# Altium schematic sheet 03_IO-CONNECTION.SchDoc(03_IO-CONNECTION)
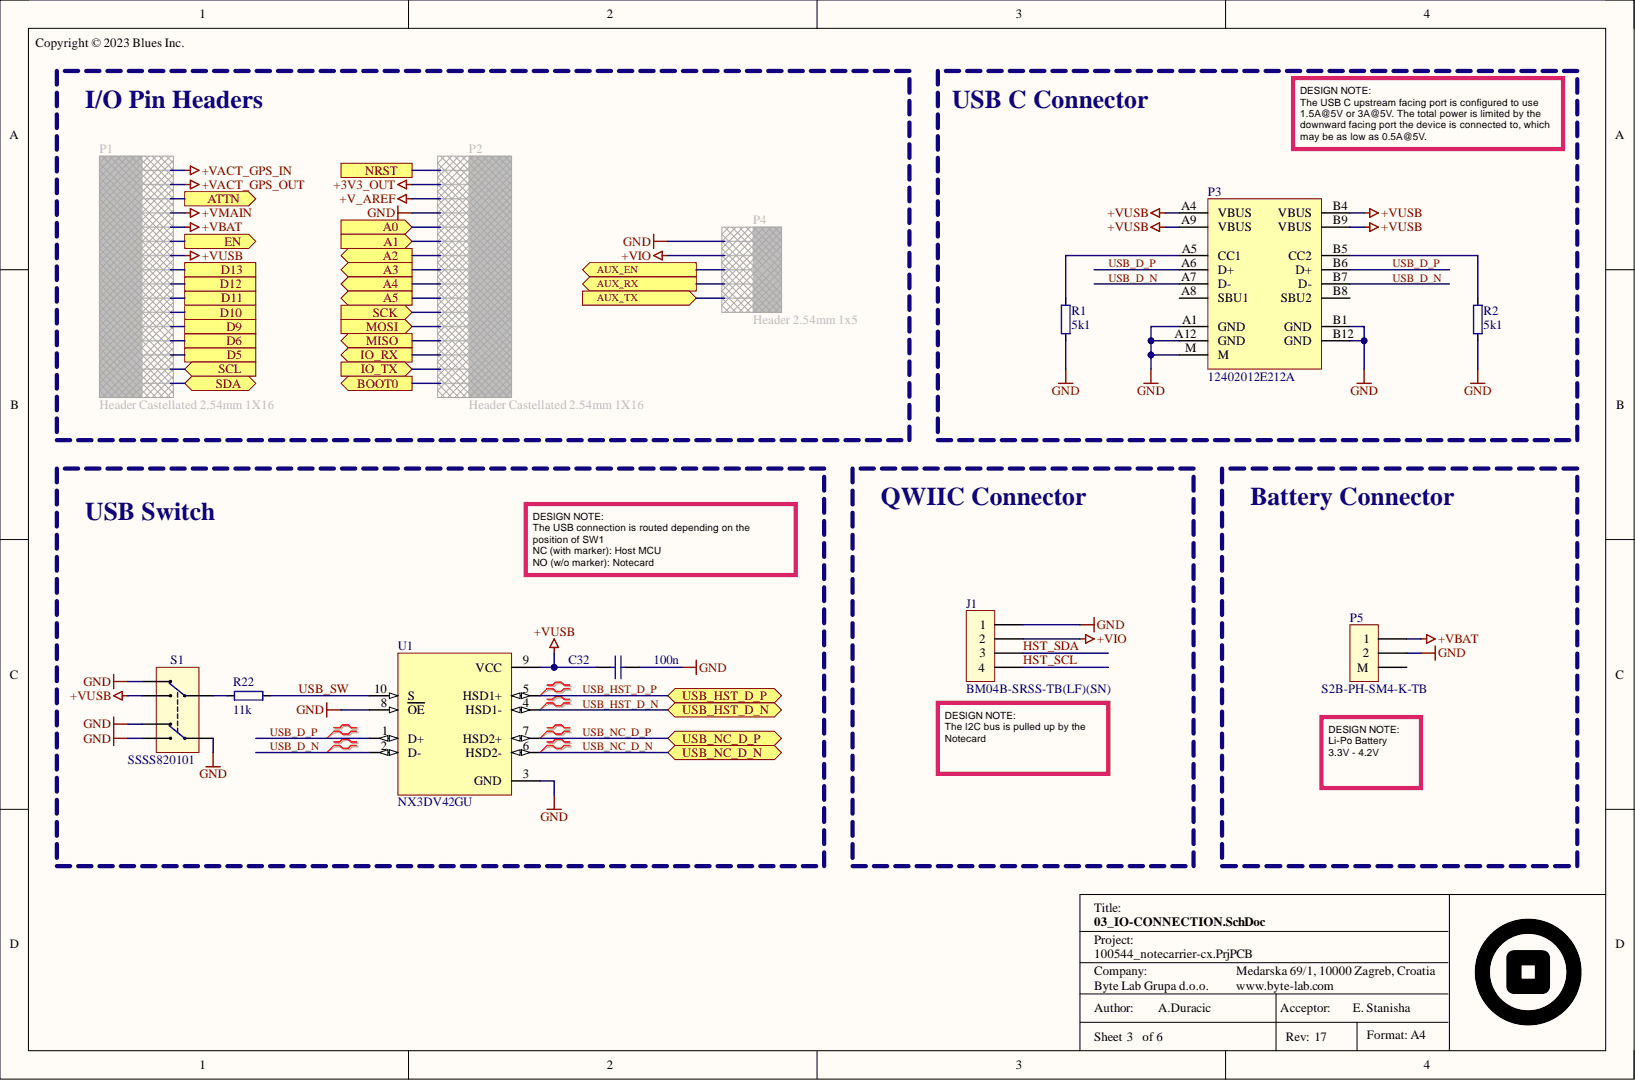
[diagram p0: 03_IO-CONNECTION.SchDoc]

## Parts
C32  100n  [C-0402]
J1  BM04B-SRSS-TB(LF)(SN)  [Conn BM04B-SRSS-TB(LF)(SN)]
P1  Header Castellated 2.54mm 1X16  [Castellated Header 2.54mm 1X16]
P2  Header Castellated 2.54mm 1X16  [Castellated Header 2.54mm 1X16]
P3  12402012E212A  [CONN_12402012E212A]
P4  Header 2.54mm 1x5  [Castellated Header 2.54mm 1X5]
P5  S2B-PH-SM4-K-TB  [Conn S2B-PH-SM4]
R1  5k1  [R-0402]
R2  5k1  [R-0402]
R22  11k  [R-0402]
S1  SSSS820101  [Slide Switch SSSS820101]
U1  NX3DV42GU  [QFN40P140X180X50-10N]

## Ports
A0, A1, A2, A3, A4, A5, ATTN, AUX_EN, AUX_RX, AUX_TX, BOOT0, D5, D6, D9, D10, D11, D12, D13, EN, IO_RX, IO_TX, MISO, MOSI, NRST, SCK, SCL, SDA, USB_HST_D_N, USB_HST_D_P, USB_NC_D_N, USB_NC_D_P

## Nets
+3V3_OUT: P2.15
+V_AREF: P2.14
+VACT_GPS_IN: P1.1
+VACT_GPS_OUT: P1.2
+VBAT: P1.5 P5.1
+VIO: J1.2 P4.2
+VMAIN: P1.4
+VUSB: C32.2 P1.7 P3.A4(VBUS) P3.A9(VBUS) P3.B4(VBUS) P3.B9(VBUS) S1.1 U1.9(VCC)
GND: C32.1 J1.1 J1.M P2.13 P3.A1(GND) P3.A12(GND) P3.B1(GND) P3.B12(GND) P3.M P4.1 P5.2 R1.1 R2.2 S1.3 S1.4 S1.5 S1.6 U1.3(GND) U1.8(OE)
A0: P2.12 ; port A0
A1: P2.11 ; port A1
A2: P2.10 ; port A2
A3: P2.9 ; port A3
A4: P2.8 ; port A4
A5: P2.7 ; port A5
ATTN: P1.3 ; port ATTN
AUX_EN: P4.3 ; port AUX_EN
AUX_RX: P4.4 ; port AUX_RX
AUX_TX: P4.5 ; port AUX_TX
BOOT0: P2.1 ; port BOOT0
D5: P1.14 ; port D5
D6: P1.13 ; port D6
D9: P1.12 ; port D9
D10: P1.11 ; port D10
D11: P1.10 ; port D11
D12: P1.9 ; port D12
D13: P1.8 ; port D13
EN: P1.6 ; port EN
HST_SCL: J1.4
HST_SDA: J1.3
IO_RX: P2.3 ; port IO_RX
IO_TX: P2.2 ; port IO_TX
MISO: P2.4 ; port MISO
MOSI: P2.5 ; port MOSI
NRST: P2.16 ; port NRST
SCK: P2.6 ; port SCK
SCL: P1.15 ; port SCL
SDA: P1.16 ; port SDA
USB_D_N: P3.A7(D-) P3.B7(D-) U1.2(D-)
USB_D_P: P3.A6(D+) P3.B6(D+) U1.1(D+)
USB_HST_D_N: U1.4(HSD1-) ; port USB_HST_D_N
USB_HST_D_P: U1.5(HSD1+) ; port USB_HST_D_P
USB_NC_D_N: U1.6(HSD2-) ; port USB_NC_D_N
USB_NC_D_P: U1.7(HSD2+) ; port USB_NC_D_P
USB_SW: R22.2 U1.10(S)
NetP3_A5: P3.A5(CC1) R1.2
NetP3_B5: P3.B5(CC2) R2.1
NetR22_1: R22.1 S1.2

Not connected: P3 A8(SBU1) B8(SBU2); P5 M

## Library data of the parts
C32 = C0402-100n-16V-X7R: Capacitor ceramic 100n ±10% X7R 16V 0402; BL Part Number: 2000-908; Checked_By: Dodig; Drawn_By: Puskar; Component Kind: Standard; DateModified: 27-08-2013; Library Name: BL_Passive.SchLib; Manufacturer: N/A; Manufacturer Part Number: N/A; Simulation: CAP; Supplier 1: Digi-Key; Supplier Part Number 1: 490-3261-1-ND
J1 = Conn BM04B-SRSS-TB(LF)(SN): Conn Header, 1x4, 1.00mm, Tin plated, VERT, SMD; BL Part Number: 2007-322; Manufacturer: JST; Checked_By: Marsic; Drawn_By: Golubic; Component Kind: Standard; DateModified: 29-01-2024; Library Name: BL_Mechanical.SchLib; Manufacturer Part Number: BM04B-SRSS-TB(LF)(SN)
P1 P2 = Conn Header Castellated 2.54mm 1X16: 2.54mm pitch 16 pin (1x16) top entry wire to board connector THT; BL Part Number: 2001-478; Drawn_By: Marsic; Component Kind: Standard; DateModified: 07-12-2013; Library Name: BL_Mechanical.SchLib; Manufacturer: N/A; Manufacturer Part Number: N/A
P3 = Conn USB C 12402012E212A: CONN RCP USB2.0 TYP C 24P SMD RA; BL Part Number: 2008-437; Manufacturer: Amphenol; Checked_By: Hlupic; Drawn_By: Anic-Ivicic; Component Kind: Standard; DateModified: 19-03-2024; Library Name: BL_Mechanical.SchLib; Manufacturer Part Number: 12402012E212A; Supplier 1: Digi-Key; Supplier Part Number 1: 664-12402012E212ATR-ND
P4 = Conn Header Castellated 2.54mm 1X5: 2.54mm pitch 5 pin (1x5) top entry wire to board connector THT; BL Part Number: 2001-472; Manufacturer: Connfly; Manufacturer Part Number: DS1021-1*5SF1-1; Checked_By: Hlupic; Drawn_By: Puskar; Component Kind: Standard; DateModified: 26-01-2014; Library Name: BL_Mechanical.SchLib
P5 = Conn S2B-PH-SM4-K-TB: 2.00mm pitch 2 pin side entry wire to board connector, SMD; BL Part Number: 2007-662; Manufacturer: JST; Manufacturer Part Number: S2B-PH-SM4-K-TB(LF)(SN); Checked_By: Duracic; Drawn_By: Hamin; Component Kind: Standard; DateModified: 08-12-2025; Library Name: BL_Mechanical.SchLib; Supplier 1: Digi-Key
R1 R2 = R0402-5k1-1: Resistor 5k1 ±1% 0402; BL Part Number: 2004-098; Checked_By: Hamin; Drawn_By: PuskarJ; Component Kind: Standard; DateModified: 21-09-2018; Library Name: BL_Passive.SchLib; Manufacturer: N/A; Manufacturer Part Number: N/A; Supplier 1: Digi-Key; Supplier Part Number 1: P5.10KLCT-ND
R22 = R0402-11k-1: Resistor 11k ±1% 0402; BL Part Number: 2004-237; Checked_By: Marsic; Drawn_By: PuskarJ; Component Kind: Standard; DateModified: 18-12-2018; Library Name: BL_Passive.SchLib; Manufacturer: N/A; Manufacturer Part Number: N/A
S1 = Switch slide SSSS820101: Slide Switch DPDT on-on, 5V/300mA; BL Part Number: 2009-940; Manufacturer: Alps Alpine; Checked_By: Duracic; Drawn_By: Klaic; Component Kind: Standard; DateModified: 08-12-2025; Library Name: BL_Mechanical.SchLib; Manufacturer Part Number: SSSS820101
U1 = NX3DV42GU: IC ANALOG USB SWITCH DPDT 10-XQFN; BL Part Number: 2005-299; Manufacturer: NXP; Checked_By: Hamin; Drawn_By: Hlupić; Component Kind: Standard; DateModified: 30-10-2020; Library Name: BL_Digital.SchLib; Manufacturer Part Number: NX3DV42GU; Supplier 1: Digi-Key; Supplier Part Number 1: 568-12388-1-ND
